# Altium schematic sheet KC4D_EXT_EM_V1.2.SchDoc(KC4D_EXT_EM_V1.2)
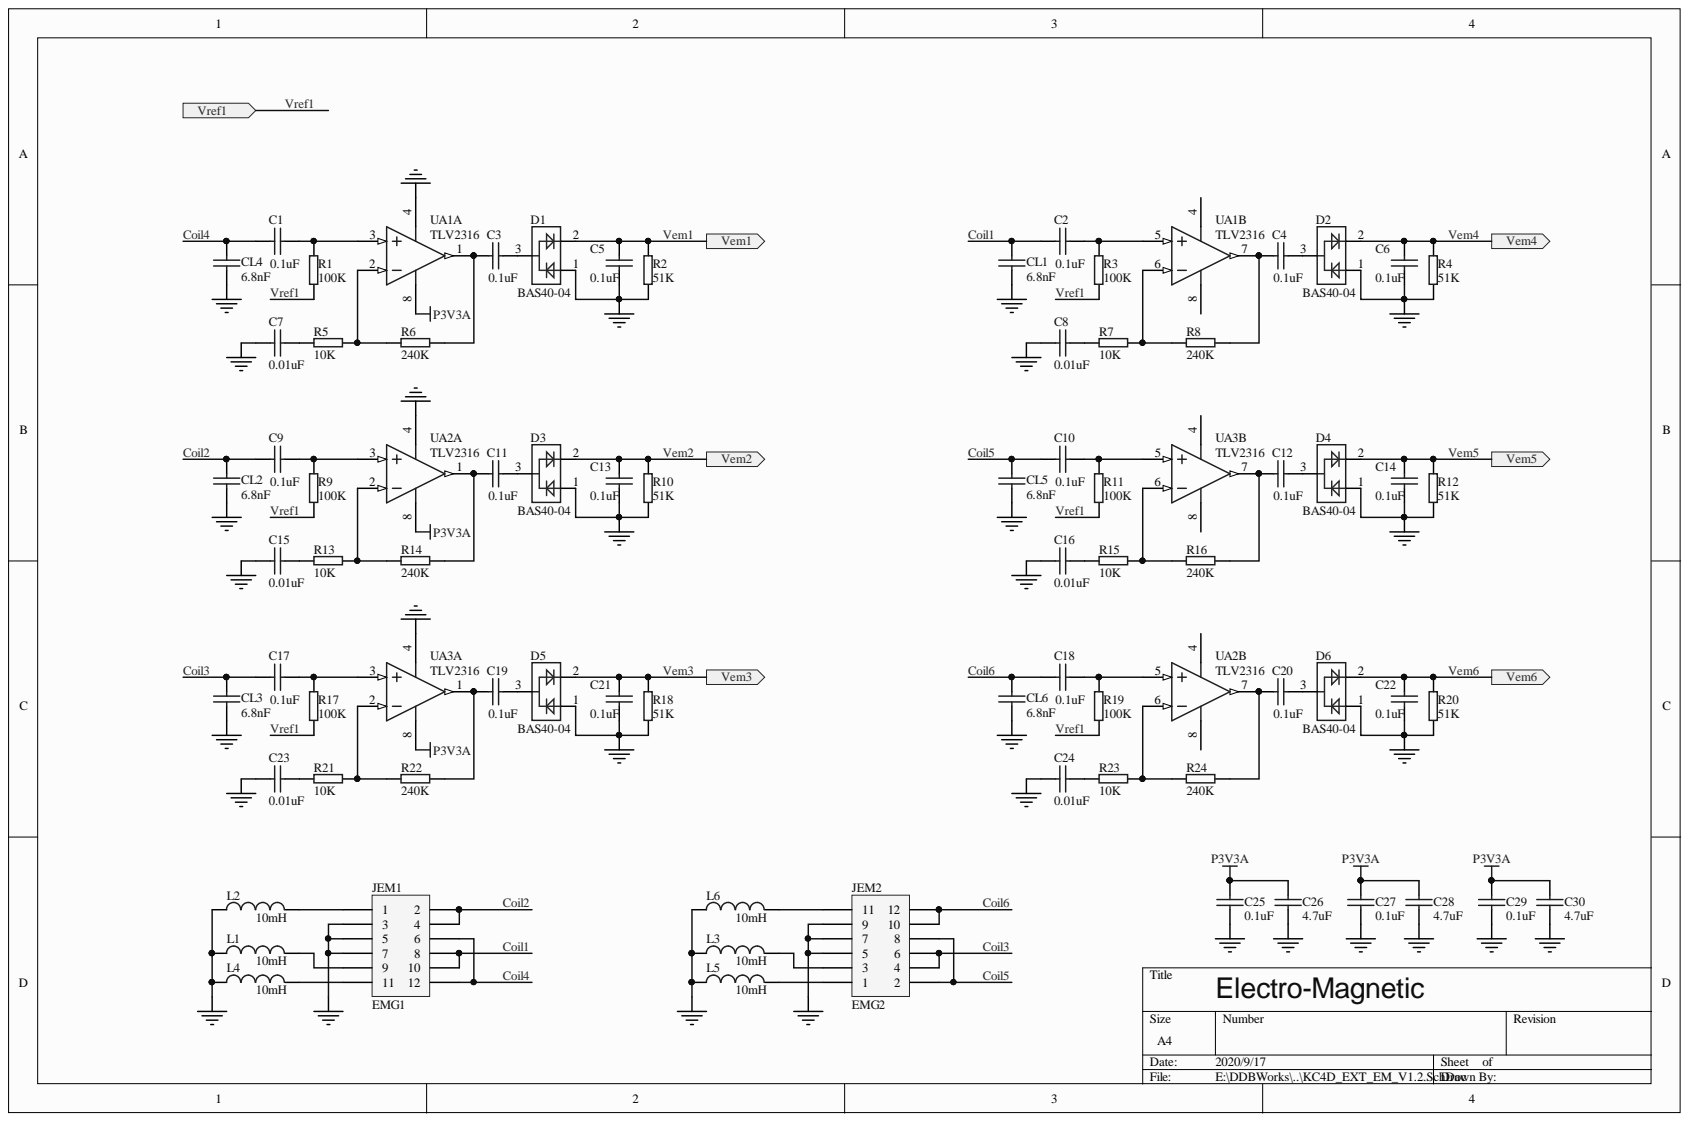
[diagram p0: KC4D_EXT_EM_V1.2.SchDoc]

## Parts
C1  0.1uF  [C0603J]
C2  0.1uF  [C0603J]
C3  0.1uF  [C0603J]
C4  0.1uF  [C0603J]
C5  0.1uF  [C0603J]
C6  0.1uF  [C0603J]
C7  0.01uF  [C0603J]
C8  0.01uF  [C0603J]
C9  0.1uF  [C0603J]
C10  0.1uF  [C0603J]
C11  0.1uF  [C0603J]
C12  0.1uF  [C0603J]
C13  0.1uF  [C0603J]
C14  0.1uF  [C0603J]
C15  0.01uF  [C0603J]
C16  0.01uF  [C0603J]
C17  0.1uF  [C0603J]
C18  0.1uF  [C0603J]
C19  0.1uF  [C0603J]
C20  0.1uF  [C0603J]
C21  0.1uF  [C0603J]
C22  0.1uF  [C0603J]
C23  0.01uF  [C0603J]
C24  0.01uF  [C0603J]
C25  0.1uF  [C0603J]
C26  4.7uF  [C0603J]
C27  0.1uF  [C0603J]
C28  4.7uF  [C0603J]
C29  0.1uF  [C0603J]
C30  4.7uF  [C0603J]
CL1  6.8nF  [C103THRU]
CL2  6.8nF  [C103THRU]
CL3  6.8nF  [C103THRU]
CL4  6.8nF  [C103THRU]
CL5  6.8nF  [C103THRU]
CL6  6.8nF  [C103THRU]
D1  BAS40-04  [SOT23]
D2  BAS40-04  [SOT23]
D3  BAS40-04  [SOT23]
D4  BAS40-04  [SOT23]
D5  BAS40-04  [SOT23]
D6  BAS40-04  [SOT23]
JEM1  EMG1  [HDR2X6H]
JEM2  EMG2  [HDR2X6H]
L1  10mH  [L472V]
L2  10mH  [L472V]
L3  10mH  [L472V]
L4  10mH  [L472H]
L5  10mH  [L472H]
L6  10mH  [L472H]
R1  100K  [R0603J]
R2  51K  [R0603J]
R3  100K  [R0603J]
R4  51K  [R0603J]
R5  10K  [R0603J]
R6  240K  [R0603J]
R7  10K  [R0603J]
R8  240K  [R0603J]
R9  100K  [R0603J]
R10  51K  [R0603J]
R11  100K  [R0603J]
R12  51K  [R0603J]
R13  10K  [R0603J]
R14  240K  [R0603J]
R15  10K  [R0603J]
R16  240K  [R0603J]
R17  100K  [R0603J]
R18  51K  [R0603J]
R19  100K  [R0603J]
R20  51K  [R0603J]
R21  10K  [R0603J]
R22  240K  [R0603J]
R23  10K  [R0603J]
R24  240K  [R0603J]
UA1  TLV2316  [M08A_N]  (parts A,B)
UA2  TLV2316  [M08A_N]  (parts A,B)
UA3  TLV2316  [M08A_N]  (parts A,B)

## Ports
Vem1, Vem2, Vem3, Vem4, Vem5, Vem6, Vref1

## Nets
GND: C5.2 C6.2 C7.2 C8.2 C13.2 C14.2 C15.2 C16.2 C21.2 C22.2 C23.2 C24.2 C25.2 C26.2 C27.2 C28.2 C29.2 C30.2 CL1.1 CL2.1 CL3.1 CL4.1 CL5.1 CL6.1 D1.1 D2.1 D3.1 D4.1 D5.1 D6.1 JEM1.3 JEM1.5 JEM1.7 JEM2.5 JEM2.7 JEM2.9 L1.1 L2.1 L3.2 L4.1 L5.2 L6.2 R2.2 R4.2 R10.2 R12.2 R18.2 R20.2 UA1.4 UA2.4 UA3.4
P3V3A: C25.1 C26.1 C27.1 C28.1 C29.1 C30.1 UA1.8 UA2.8 UA3.8
Coil1: C2.2 CL1.2 JEM1.8 JEM1.10
Coil2: C9.2 CL2.2 JEM1.2 JEM1.4
Coil3: C17.2 CL3.2 JEM2.4 JEM2.6
Coil4: C1.2 CL4.2 JEM1.6 JEM1.12
Coil5: C10.2 CL5.2 JEM2.2 JEM2.8
Coil6: C18.2 CL6.2 JEM2.10 JEM2.12
Vem1: C5.1 D1.2 R2.1 ; port Vem1
Vem2: C13.1 D3.2 R10.1 ; port Vem2
Vem3: C21.1 D5.2 R18.1 ; port Vem3
Vem4: C6.1 D2.2 R4.1 ; port Vem4
Vem5: C14.1 D4.2 R12.1 ; port Vem5
Vem6: C22.1 D6.2 R20.1 ; port Vem6
Vref1: R1.1 R3.1 R9.1 R11.1 R17.1 R19.1 ; port Vref1
NetC1_1: C1.1 R1.2 UA1.3
NetC2_1: C2.1 R3.2 UA1.5
NetC3_1: C3.1 D1.3
NetC3_2: C3.2 R6.1 UA1.1
NetC4_1: C4.1 D2.3
NetC4_2: C4.2 R8.1 UA1.7
NetC7_1: C7.1 R5.2
NetC8_1: C8.1 R7.2
NetC9_1: C9.1 R9.2 UA2.3
NetC10_1: C10.1 R11.2 UA3.5
NetC11_1: C11.1 D3.3
NetC11_2: C11.2 R14.1 UA2.1
NetC12_1: C12.1 D4.3
NetC12_2: C12.2 R16.1 UA3.7
NetC15_1: C15.1 R13.2
NetC16_1: C16.1 R15.2
NetC17_1: C17.1 R17.2 UA3.3
NetC18_1: C18.1 R19.2 UA2.5
NetC19_1: C19.1 D5.3
NetC19_2: C19.2 R22.1 UA3.1
NetC20_1: C20.1 D6.3
NetC20_2: C20.2 R24.1 UA2.7
NetC23_1: C23.1 R21.2
NetC24_1: C24.1 R23.2
NetJEM1_1: JEM1.1 L2.2
NetJEM1_9: JEM1.9 L1.2
NetJEM1_11: JEM1.11 L4.2
NetJEM2_1: JEM2.1 L5.1
NetJEM2_3: JEM2.3 L3.1
NetJEM2_11: JEM2.11 L6.1
NetR5_1: R5.1 R6.2 UA1.2
NetR7_1: R7.1 R8.2 UA1.6(R3)
NetR13_1: R13.1 R14.2 UA2.2
NetR15_1: R15.1 R16.2 UA3.6
NetR21_1: R21.1 R22.2 UA3.2
NetR23_1: R23.1 R24.2 UA2.6

## Library data of the parts
C1-C30 = CAP: PCB3D: 0805_0_0000_C; Part Field 4: 30pF; Part Field 3: Altium Limited; Part Field 2: July-2002: Re-released for DXP Platform.; Part Field 1: 8-Jun-2000; Component Kind: Standard
CL1-CL6 = CAP: Capacitor; Component Kind: Standard
D1-D6 = MMBD7000: PCB3D: SOT23-5_0_0000_U; Library Name: DTE1.SchLib; Component Kind: Standard
JEM1 JEM2 = Header 6X2H: Header, 6-Pin, Dual row, Right Angle; Signal Integrity: Connector; Library Name: Miscellaneous Connectors.IntLib; LatestRevisionNote: Re-released for DXP Platform.; LatestRevisionDate: 17-Jul-2002; Component Kind: Standard; Publisher: Altium Limited
L1-L6 = Inductor: Code_IEC: 1005; Value: 10mH; Published: 8-Jun-2000; PackageReference: 0402-A; Library Name: Miscellaneous Devices.IntLib; LatestRevisionNote: Re-released for DXP Platform.; LatestRevisionDate: 17-Jul-2002; Description: Inductor; Component Kind: Standard; Code_JEITA: 0402; Publisher: Altium Limited
R1-R24 = Ra_DTE: PCB3D: 0805_0_0000_R; Library Name: DTE1.SchLib; Component Kind: Standard
UA1-UA3 = LM6132AIMX: Dual Low Power 10 MHz Rail-to-Rail I/O Operational Amplifier, 8-pin Narrow SOIC; Manufacturer: National Semiconductor; PowerWise: N; PackageTopMarking: NSZXTT*LM61*32AIM; CompType: Amplifiers; Simulation: LM6132A; RoHS: N; Published: 1-Mar-2010; PinCount: 8; PartNumber: LM6132AIMX; PackageVersion: revM, May-2009; PackageReference: M08A; PackageDescription: SOIC Narrow, 4.9 x 3.9 x 1.45mm, 8 Lead, 1.27mm Pitch; Library Name: NSC Amplifier.IntLib; DatasheetVersion: Nov-2004; ComponentLink3URL: http://www.national.com/packaging/folders/m08a.html; ComponentLink3Description: M08A Package Page; ComponentLink2URL: http://www.national.com/pf/LM/LM6132.html; ComponentLink2Description: LM6132 Web Page; ComponentLink1URL: http://www.national.com/ds/LM/LM6132.pdf; ComponentLink1Description: LM6132 Datasheet; Component Kind: Standard; Code_JEDEC: MS-012-AA; Code_IPC: SOIC127P600-8; Publisher: Altium Limited
